# Altium schematic sheet UART_CH340G.SchDoc
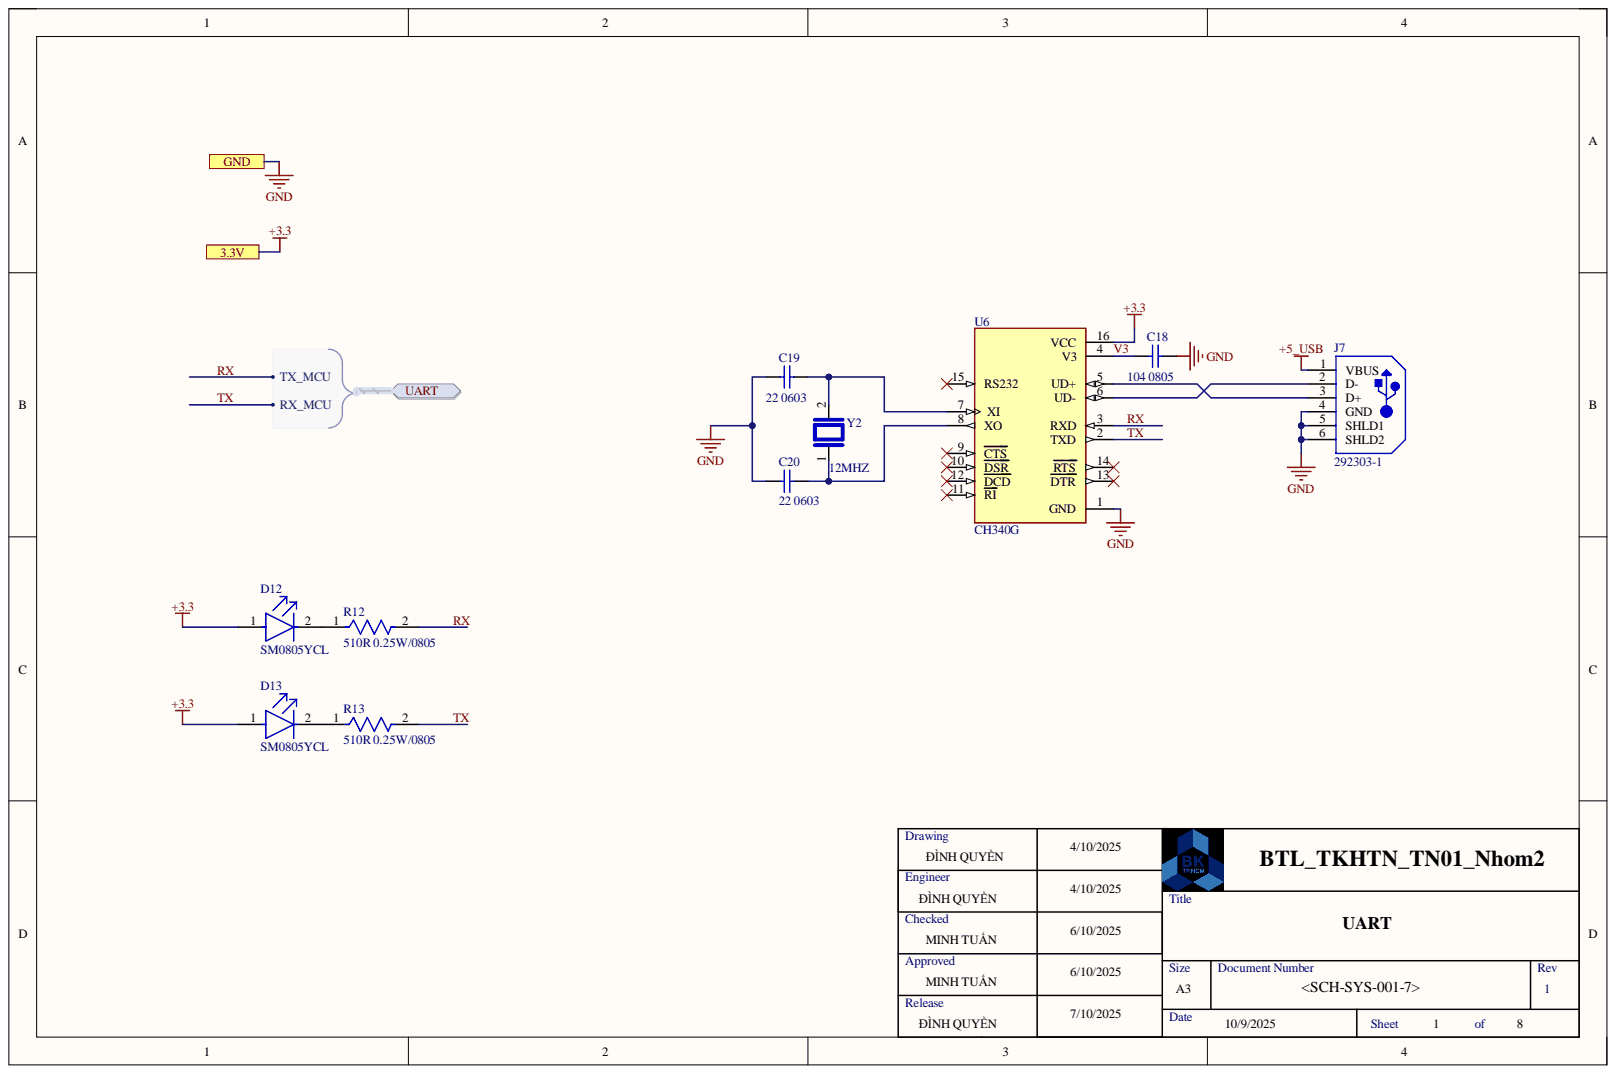
[diagram p0: UART_CH340G.SchDoc]

## Parts
C18  104 0805  Case-EIA: 0805  [CAPC0805(2012)145_M]
C19  22 0603  [CAPC1608X92X38ML15T08]
C20  22 0603  [CAPC1608X92X38ML15T08]
D12  SM0805YCL  [FP-SM0805YCL-MFG]
D13  SM0805YCL  [FP-SM0805YCL-MFG]
J7  292303-1  [TE-292303-1_V]
R12  510R 0.25W/0805  [FP-AF0805-IPC_C]
R13  510R 0.25W/0805  [FP-AF0805-IPC_C]
U6  CH340G  [SOIC127P600X180-16N]
Y2  12MHZ  [XTAL_HC49P488W43L1150T466H350]

## Ports
3.3V, GND, UART, UART.RX_MCU, UART.TX_MCU

## Nets
+5_USB: J7.1(VBUS)
+3.3: D12.1 D13.1 U6.16(VCC) ; port 3.3V
GND: C18.2 C19.2 C20.2 J7.4(GND) J7.5(SHLD1) J7.6(SHLD2) U6.1(GND) ; port GND
RX: R12.2 U6.3(RXD) ; port UART
TX: R13.2 U6.2(TXD) ; port UART
V3: C18.1 U6.4(V3)
NetC19_1: C19.1 U6.7(XI) Y2.2
NetC20_1: C20.1 U6.8(XO) Y2.1
NetD12_2: D12.2 R12.1
NetD13_2: D13.2 R13.1
NetJ7_2: J7.2(D-) U6.6(UD-)
NetJ7_3: J7.3(D+) U6.5(UD+)
NetU6_9: U6.9(CTS)
NetU6_10: U6.10(DSR)
NetU6_11: U6.11(RI)
NetU6_12: U6.12(DCD)
NetU6_13: U6.13(DTR)
NetU6_14: U6.14(RTS)
NetU6_15: U6.15(RS232)

## Library data of the parts
C18 = CMP-1036-03968-1: CAP 15nF 100V ±10% 0805 (2012 Metric) Thickness 1.45mm SMD; Case-Metric: 2012; Tolerance: Â±10%; Component Kind: Standard; Library Name: Altium Content Vault; Max Thickness: 1.45 mm; Rated Voltage: 100 V; Technology: SMT; Value: 15nF
C19 C20 = CMP-2006-01201-1: Manufacturer: Vitramon; Part Number: VJ0603Y103JXXAC; Component Kind: Standard; Library Name: Altium Content Vault
D12 D13 = CMP-35283-000007-1: LED YELLOW CLEAR 0805 SMD; Dominant Wavelength: 592nm; Forward Current: 2mA; Forward Voltage: 1.9V; Lens Color: Colorless; Luminous Intensity: 11.5mcd; Viewing Angle: 130°; Case Code (Imperial): 0805; Case Code (Metric): 2012; Case/Package: 0805; Color: Yellow; Component Kind: Standard; Height: 0.8mm; Illumination Color: Yellow; Lead Free: Lead Free; Length: 2mm; Lens Transparency: Clear; Library Name: Altium Content Vault; Max Operating Temperature: 80°C; Min Operating Temperature: -30°C; Mount: Surface Mount; Package Quantity: 4000; Packaging: Tape and Reel; Test Current: 2mA; Width: 1.2mm
J7 = CMP-2000-05404-1: 1 Port Right Angle Through Hole Mount Female Type A USB Connector, 4 Position, -55 to 85 degC, RoHS, Tube; Manufacturer: TE Connectivity; Connector Mounting: PCB; Connector Type: USBA; Contact Termination Type: Solder; Gender: Receptacle; No. of Contacts: 4; SVHC: NoSVHC(16-Jun-2014); Component Kind: Standard; Contact Material: Copper; Contact Plating: Gold; Datasheet URL: http://www.farnell.com/cad/1653030.pdf; Datasheet Version: Feb-12; Library Name: Altium Content Vault; Manufacturer URL: http://www.te.com/; Package Description: 4-Pin Through Hole Device, Body 14 x 13.13 mm, Pitch 2.5 mm; Package Reference: 292303-1
R12 R13 = CMP-03412-045285-1: Anti-Sulfurated Chip Resistor Automotive Grade 75Ω 1% 0.125W ±100ppm/°C 0805; Max Power Dissipation: 125mW; Resistance: 75R; Case/Package: 0805; Component Kind: Standard; Features: Moisture Resistant; Height: 0.024inch; Length: 0.079inch; Library Name: Altium Content Vault; Max Operating Temperature: 155°C; Min Operating Temperature: -55°C; Number of Terminations: 2; Packaging: Tape and Reel; Resistor Type: Thick Film; RoHS Compliant: Yes; Series: AF; Temperature Coefficient: 100ppm/°C; Tolerance: 1%; Width: 0.049inch
U6 = CH340G: USB Interface Integrated Circuit; MF: WCH; Availability: Not in stock; Check_prices: https://www.snapeda.com/parts/Ch340G/WCH/view-part/?ref=eda; Component Kind: Standard; Library Name: CH340G.IntLib; MP: Ch340G; Package: None; Price: None; SnapEDA_Link: https://www.snapeda.com/parts/Ch340G/WCH/view-part/?ref=snap
Y2 = HC-49/U-S16000000ABJB: 16 MHz Â±30ppm Crystal 18pF 50 Ohms HC-49/US; MF: Citizen Finedevice; Check_prices: https://www.snapeda.com/parts/HC-49/U-S16000000ABJB/Citizen/view-part/?ref=eda; Component Kind: Standard; Library Name: HC-49_U-S16000000ABJB.IntLib; MP: HC-49/U-S16000000ABJB; Package: HC-49/US Citizen Finetech Miyota; SnapEDA_Link: https://www.snapeda.com/parts/HC-49/U-S16000000ABJB/Citizen/view-part/?ref=snap
